# Altium schematic sheet FEV-60_12V_Module.SchDoc
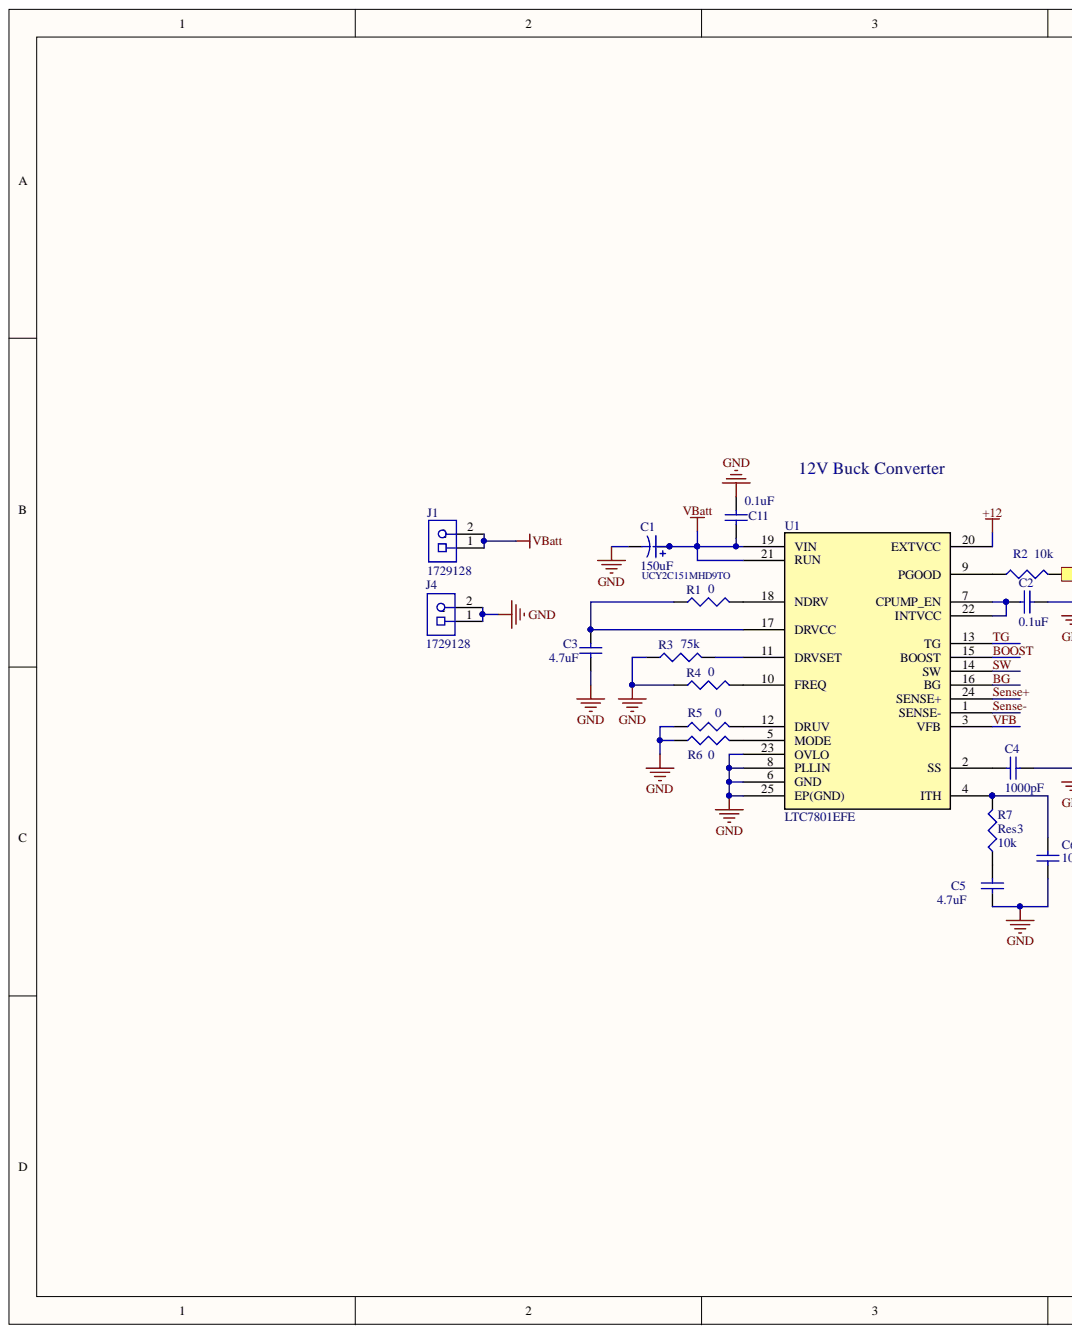
[diagram p0: FEV-60_12V_Module.SchDoc, left]
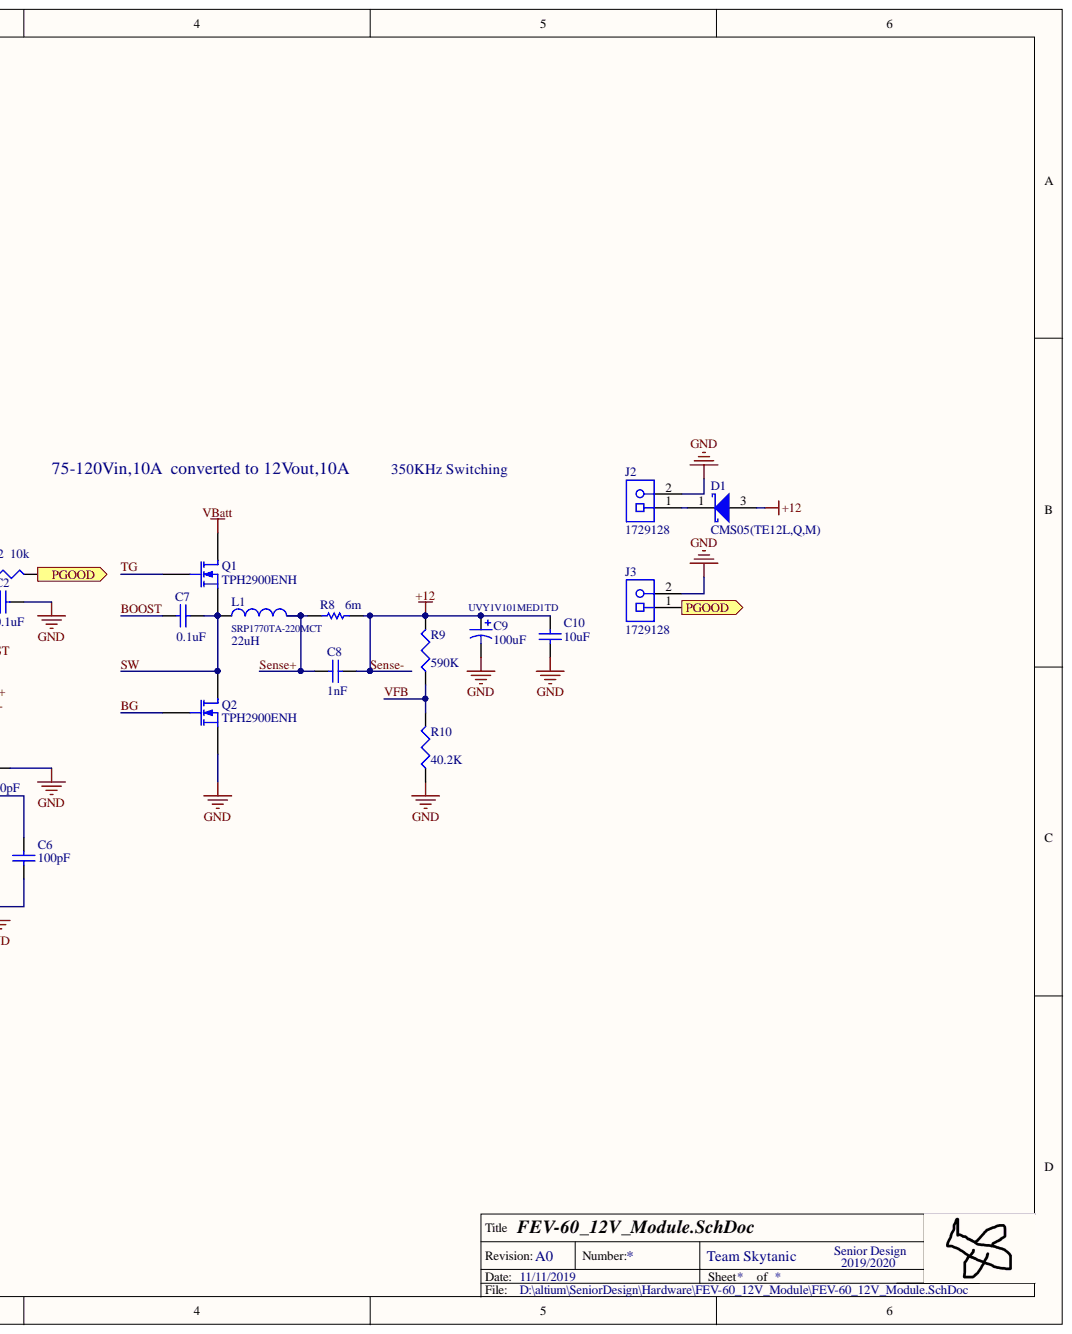
[diagram p1: FEV-60_12V_Module.SchDoc, right]

## Parts
C1  UCY2C151MHD9TO  value 150uF  [UCS2D151MHD1TN]
C2  value 0.1uF  [1608[0603]]  (hidden comment: Cap Semi)
C3  value 4.7uF  [1608[0603]]  (hidden comment: Cap Semi)
C4  value 1000pF  [1608[0603]]  (hidden comment: Cap Semi)
C5  value 4.7uF  [1608[0603]]  (hidden comment: Cap Semi)
C6  value 100pF  [1608[0603]]  (hidden comment: Cap Semi)
C7  value 0.1uF  [1608[0603]]  (hidden comment: Cap Semi)
C8  value 1nF  [1608[0603]]  (hidden comment: Cap Semi)
C9  UVY1V101MED1TD  value 100uF  [UVY1V101MED1TD]
C10  value 10uF  [1608[0603]]  (hidden comment: Cap Semi)
C11  value 0.1uF  [C1206]  (hidden comment: Cap Semi)
D1  CMS05(TE12L,Q,M)  [CMS05(TE12L,Q,M)]
J1  1729128  [PHOE-1729128-2_V]
J2  1729128  [PHOE-1729128-2_V]
J3  1729128  [PHOE-1729128-2_V]
J4  1729128  [PHOE-1729128-2_V]
L1  SRP1770TA-220MCT  value 22uH  [SRP1770TA-220MCT]
Q1  TPH2900ENH  [TPH2900ENH]
Q2  TPH2900ENH  [TPH2900ENH]
R1  value 0  [J1-0603]  (hidden comment: Res3)
R2  value 10k  [J1-0603]  (hidden comment: Res3)
R3  value 75k  [J1-0603]  (hidden comment: Res3)
R4  value 0  [J1-0603]  (hidden comment: Res3)
R5  value 0  [J1-0603]  (hidden comment: Res3)
R6  value 0  [J1-0603]  (hidden comment: Res3)
R7  Res3  value 10k  [J1-0603]
R8  6m  [RESC2512(6432)_L]
R9  value 590K  [J1-0603]  (hidden comment: Res3)
R10  value 40.2K  [J1-0603]  (hidden comment: Res3)
U1  LTC7801EFE  [LTC7801]

## Ports
PGOOD

## Nets
GND: C1.2 C2.1 C3.1 C4.1 C5.1 C6.1 C9.2 C10.1 C11.1 J2.2 J3.2 J4.1 J4.2 Q2.3 R3.1 R4.1 R5.1 R6.1 R10.1 U1.6(GND) U1.8(PLLIN) U1.23(OVLO) U1.25(EP(GND))
VBatt: C1.1 C11.2 J1.1 J1.2 Q1.1 U1.19(VIN) U1.21(RUN)
BG: Q2.2 U1.16(BG)
BOOST: C7.2 U1.15(BOOST)
PGOOD: J3.1 R2.2 ; port PGOOD
Sense+: C8.2 L1.2 R8.1 U1.24(SENSE+)
Sense-: C8.1 C9.1 C10.2 D1.3 R8.2 R9.2 U1.1(SENSE-) U1.20(EXTVCC)
SW: C7.1 L1.1 Q1.3 Q2.1 U1.14(SW)
TG: Q1.2 U1.13(TG)
VFB: R9.1 R10.2 U1.3(VFB)
NetC2_2: C2.2 U1.7(CPUMP_EN) U1.22(INTVCC)
NetC3_2: C3.2 R1.1 U1.17(DRVCC)
NetC4_2: C4.2 U1.2(SS)
NetC5_2: C5.2 R7.1
NetC6_2: C6.2 R7.2 U1.4(ITH)
NetD1_1: D1.1 J2.1
NetR1_2: R1.2 U1.18(NDRV)
NetR2_1: R2.1 U1.9(PGOOD)
NetR3_2: R3.2 U1.11(DRVSET)
NetR4_2: R4.2 U1.10(FREQ)
NetR5_2: R5.2 U1.12(DRUV)
NetR6_2: R6.2 U1.5(MODE)

## Library data of the parts
C1 C9 = Cap Pol3: Polarized Capacitor (Surface Mount); Component Kind: Standard; ComponentLink1Description: Digikey; LatestRevisionDate: 29-May-2009; LatestRevisionNote: IPC-7351 Footprint Added.; Library Name: Miscellaneous Devices.IntLib; PackageDescription: Chip Capacitor; PackageReference: C0805; Published: 8-Jun-2000; Signal Integrity: Cap Pol; Simulation: CAP; Publisher: Altium Limited
  C1: ComponentLink1URL: https://www.digikey.com/product-detail/en/nichicon/UCY2C151MHD9TO/493-13302-1-ND/4333061
  C9: ComponentLink1URL: https://www.digikey.com/product-detail/en/nichicon/UVY1V101MED1TD/493-12937-1-ND/4328554
C2-C8 C10 C11 = Cap Semi: Capacitor (Semiconductor SIM Model); Component Kind: Standard; LatestRevisionDate: 29-May-2009; LatestRevisionNote: IPC-7351 Footprint Added.; Library Name: Miscellaneous Devices.IntLib; PackageDescription: Chip Capacitor; PackageReference: C1206; Published: 8-Jun-2000; Signal Integrity: Cap Semi; Simulation: CAP; Publisher: Altium Limited
D1 = Diode 10TQ035: Schottky Rectifier; Manufacturer: Toshiba; Note: Terminal 2 is the TAB and is electrically connected to the cathode; Component Kind: Standard; ComponentLink1Description: Manufacturer Link; ComponentLink1URL: http://www.irf.com/; ComponentLink2Description: Datasheet; ComponentLink2URL: http://www.irf.com/product-info/datasheets/data/10tq.pdf; DatasheetVersion: PD-2.057B; LatestRevisionDate: 17-Jul-2002; LatestRevisionNote: Re-released for DXP Platform.; Library Name: Miscellaneous Devices.IntLib; PackageDescription: TO, Thru-Hole, Vertical, Heatsink Mounted; 3 In-Line Leads (1 Absent); Pitch 2.54 mm; PackageReference: TO-220AC; PackageVersion: Feb-1998; Part Number: CMS05(TE12L,Q,M); Published: 8-Jun-2000; Simulation: 10TQ035; Publisher: Altium Limited
J1-J4 = CMP-2000-05815-1: PCB Terminal Block, 13.5 A, 400 V, 2-Pin THD, RoHS, Bulk; Manufacturer: Phoenix Contact; Current Rating: 13500mA; No. of Positions: 2; No. of Rows: 1; Package Version: 03/2015; Pitch: 5.08mm; Voltage Rating: 400V; Component Kind: Standard; Datasheet URL: https://datasheet.ciiva.com/16046/1729128-16046181.pdf; Datasheet Version: 12/2013; Library Name: Altium Content Vault; Manufacturer URL: http://www.phoenixcontact.com/; Mounting Technology: ThroughHole; Orientation: Horizontal; Package Description: 2-Pin Through Hole Device, Body 8.1 x 10.16 mm, Pitch 5.08 mm; Package Reference: 1729128-2; Package URL: https://www.phoenixcontact.com/online/portal/pi/pxc/product_detail_page/!ut/p/b1/3VdJjqNKFDxLH8AFycySyWY2ozFsEPOMMQaDOX1XedGL_1Vdm5YXJleknhSKeBkvI6EA8ncAo3AYoDhBQGco6KN7VURTdemj9us_IEKEZo_YHiPAEWEFmDGOHMORkqKYCORBZxgL7ZoaZYcbFFUZ3fqxSY6GOuxydWUuHqz1ynmMFygu583Bob0Ewr6Sd_l-5m-kAueHiB0jpRwZwe58wwtkpxtMYd_LmGcDRU02dRNEyWt6Y2ti5pSyJ_reIkzIulrqApdnbT11V5jnCKbYNCvhEM1MCpPByat_X379-iThf08CI5GfOPqfBeS3BRoBOdD55AKVv0iLwCMq-2BrzXWSGRH7pUGD9uwXQjiph3xL0DKQwdGa0JImiIOmBNOestqgwTHSSs2oOep-78apyXQBvNi8ZLaFjvjWvcaUEBu0MC6Wbe-d6mB0FAebo2lUWinqYaZs5DAYzV0RP9yitUjnGJ0iI9xhZkT42OCQNUJJhkgWINNQLP2JFP6OpMg3JCVg70jqHT0lvKOnhHf0lPuOnnLf0VPuWx6_dxwUIvwk5WMkT0kLL1mh61l2yZVSzG3pYV_bqo-mj6uMKwKwdnnW8Jh5RTOJzMOA5c-eJDaZQw4mTMPH02PvS_ZAqNVjVTo2WyYLkwH_KU1mmmG5kjRhDqPXLwwVHvVOjCM60-fpXvTDfMGW1PVFVS1r4e4yVDk4ZdNbkUHvqPqQSeian4UD39fNKmachqdJ1NJbyk8rXT9mXTvPk3oE5meulKGgiruPJek-4I9hTT6G8ZLOyRSm2RRVbThERQad5OS28hdt4YW4vG0stgYYzsWsK4_7y6DZMjJSCqri1kyiZR5vD7pwIrNR7QwPHTcLTI5qT7eRlpHrdGiupYlqQDJ7zy_CND8fWWBoLRXxk1RVcXiBQwbTD4Xi7KL-FuO94t9xaqweXzH; Packing: Bulk; PartNumber: 1729128; RoHS: TRUE
L1 = Inductor: SRP1770TA-330M; Code_IEC: 1005; Code_JEITA: 0402; Component Kind: Standard; ComponentLink1Description: Digikey; ComponentLink1URL: https://www.digikey.com/product-detail/en/bourns-inc/SRP1770TA-220M/SRP1770TA-220MCT-ND/5429725; LatestRevisionDate: 29-May-2009; LatestRevisionNote: IPC-7351 Footprint Added.; Library Name: Miscellaneous Devices.IntLib; PackageDescription: Chip Inductor; PackageReference: 0402-A; Published: 8-Jun-2000; Signal Integrity: Inductor; Simulation: INDUCTOR; Publisher: Altium Limited
Q1 Q2 = TPH2900ENH: N-Channel 200V 33A (Ta) 78W (Tc) Surface Mount 8-SOP Advance (5x5); Manufacturer: Toshiba; Part Number: TPH2900ENHL1QCT-ND; Component Kind: Standard; ComponentLink1Description: TPH2900ENH; ComponentLink1URL: https://www.digikey.com/product-detail/en/toshiba-semiconductor-and-storage/TPH2900ENHL1Q/TPH2900ENHL1QCT-ND/5456291; Library Name: FEV-60.SchLib
R1-R7 R9 R10 = Res3: Resistor; Component Kind: Standard; LatestRevisionDate: 29-May-2009; LatestRevisionNote: IPC-7351 Footprint Added.; Library Name: Miscellaneous Devices.IntLib; PackageDescription: Chip Resistor; PackageReference: J1-0603; Published: 8-Jun-2000; Signal Integrity: Res; Simulation: RESISTOR; Publisher: Altium Limited
R8 = CMP-1018-00006-1: 2512; Manufacturer: Rohm Semiconductor; Part Number: PMR100HZPFU6L00; Case-Metric: 6432; Power: 1 W; Tolerance: 5 %; Value: 1.50 Ohm; Case-EIA: 2512; Component Kind: Standard; Library Name: Altium Content Vault; Technology: SMT
U1 = LTC7801EFE: Buck Regulator Positive Output Step-Down DC-DC Controller IC 24-TSSOP; Manufacturer: Analog Devices; Part Number: LTC7801EFE#PBF; Component Kind: Standard; RefDes: RefDes; Type: DEV; Value: Value; Copyright: Copyright (C) 2018 Accelerated Designs. All rights reserved; Library Name: FEV-60.SchLib
